# Altium schematic sheet Sheet1.SchDoc
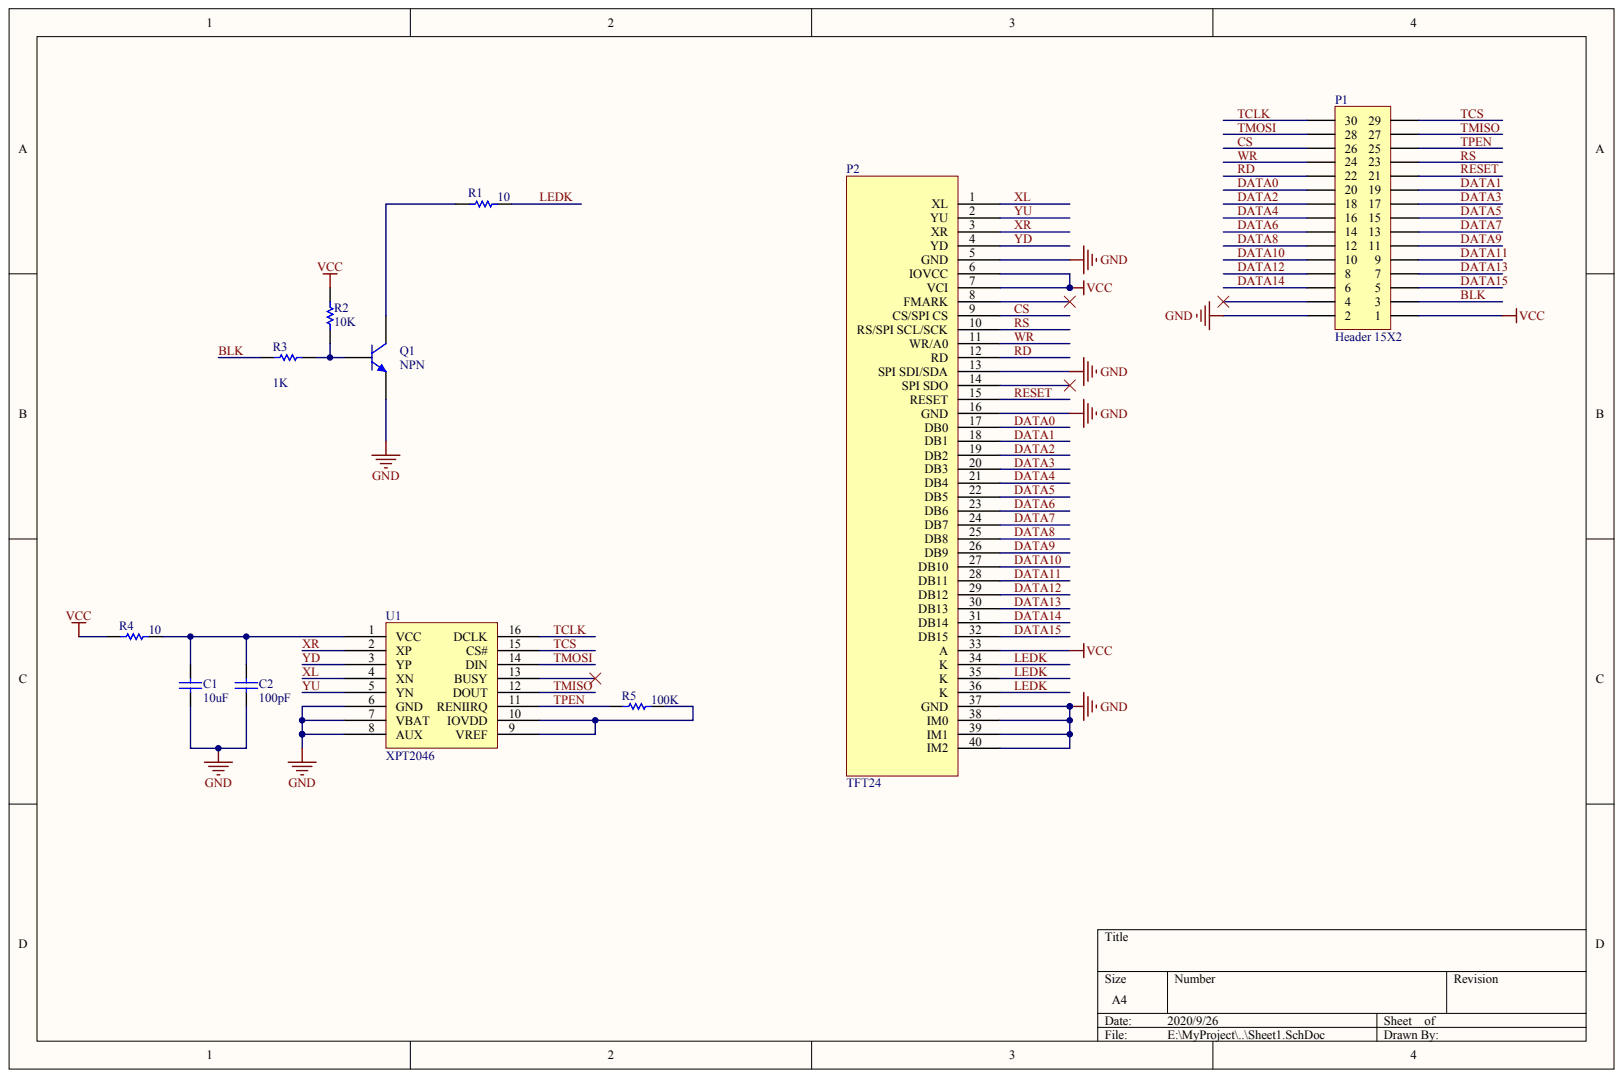
[diagram p0: Sheet1.SchDoc]

## Parts
C1  value 10uF  (hidden comment: Cap)
C2  value 100pF  (hidden comment: Cap)
P1  Header 15X2
P2  TFT24
Q1  NPN
R1  value 10  (hidden comment: Res1)
R2  value 10K  (hidden comment: Res1)
R3  value 1K  (hidden comment: Res1)
R4  value 10  (hidden comment: Res1)
R5  value 100K  (hidden comment: Res1)
U1  XPT2046

## Nets
GND: C1.2 C2.2 P1.2 P2.5(GND) P2.13(SPI_SDI/SDA) P2.16(GND) P2.37(GND) P2.38(IM0) P2.39(IM1) P2.40(IM2) Q1.3 U1.6(GND) U1.7(VBAT) U1.8(AUX)
VCC: P1.1 P2.6(IOVCC) P2.7(VCI) P2.33(A) R2.2 R4.1
BLK: P1.3 R3.1
CS: P1.26 P2.9(CS/SPI_CS)
DATA0: P1.20 P2.17(DB0)
DATA1: P1.19 P2.18(DB1)
DATA2: P1.18 P2.19(DB2)
DATA3: P1.17 P2.20(DB3)
DATA4: P1.16 P2.21(DB4)
DATA5: P1.15 P2.22(DB5)
DATA6: P1.14 P2.23(DB6)
DATA7: P1.13 P2.24(DB7)
DATA8: P1.12 P2.25(DB8)
DATA9: P1.11 P2.26(DB9)
DATA10: P1.10 P2.27(DB10)
DATA11: P1.9 P2.28(DB11)
DATA12: P1.8 P2.29(DB12)
DATA13: P1.7 P2.30(DB13)
DATA14: P1.6 P2.31(DB14)
DATA15: P1.5 P2.32(DB15)
LEDK: P2.34(K) P2.35(K) P2.36(K) R1.2
RD: P1.22 P2.12(RD)
RESET: P1.21 P2.15(RESET)
RS: P1.23 P2.10(RS/SPI_SCL/SCK)
TCLK: P1.30 U1.16(DCLK)
TCS: P1.29 U1.15(CS#)
TMISO: P1.27 U1.12(DOUT)
TMOSI: P1.28 U1.14(DIN)
TPEN: P1.25 R5.1 U1.11(RENIIRQ)
WR: P1.24 P2.11(WR/A0)
XL: P2.1(XL) U1.4(XN)
XR: P2.3(XR) U1.2(XP)
YD: P2.4(YD) U1.3(YP)
YU: P2.2(YU) U1.5(YN)
NetC1_1: C1.1 C2.1 R4.2 U1.1(VCC)
NetQ1_1: Q1.1 R1.1
NetQ1_2: Q1.2 R2.1 R3.2
NetR5_2: R5.2 U1.9(VREF) U1.10(IOVDD)

Not connected: P1 4; P2 8(FMARK) 14(SPI_SDO); U1 13(BUSY)

## Library data of the parts
C1 C2 P1 P2 Q1 R1-R5 U1 = (no symbol name)
  C1 C2: Published: 8-Jun-2000; LatestRevisionDate: 17-Jul-2002; LatestRevisionNote: Re-released for DXP Platform.; PackageReference: RAD-0.3; PackageDescription: Radial Cap, Thru-Hole; 2 Leads; 0.3 in Pin Spacing
  P1: LatestRevisionDate: 17-Jul-2002; LatestRevisionNote: Re-released for DXP Platform.
  Q1: Published: 8-Jun-2000; LatestRevisionDate: 17-Jul-2002; LatestRevisionNote: Re-released for DXP Platform.; PackageReference: TO-226-AA; PackageDescription: TO, Flat Index; 3 In-Line, Axial Leads; Body Dia. 4.8mm; Leads 0.55 x 0.51 mm (max); Code_JEDEC: TO-226-AA
  R1-R5: Published: 8-Jun-2000; LatestRevisionDate: 17-Jul-2002; LatestRevisionNote: Re-released for DXP Platform.; PackageReference: AXIAL-0.3; PackageDescription: Axial Device, Thru-Hole; 2 Leads; 0.3 in Pin Spacing
  C1 C2 P1 Q1 R1-R5: Publisher: Altium Limited
